# Altium schematic sheet PA0960_IR_Dot_Projector_IR_Flood_LED.SchDoc(PA0960_IR_Dot_Projector_IR_Flood_LED)
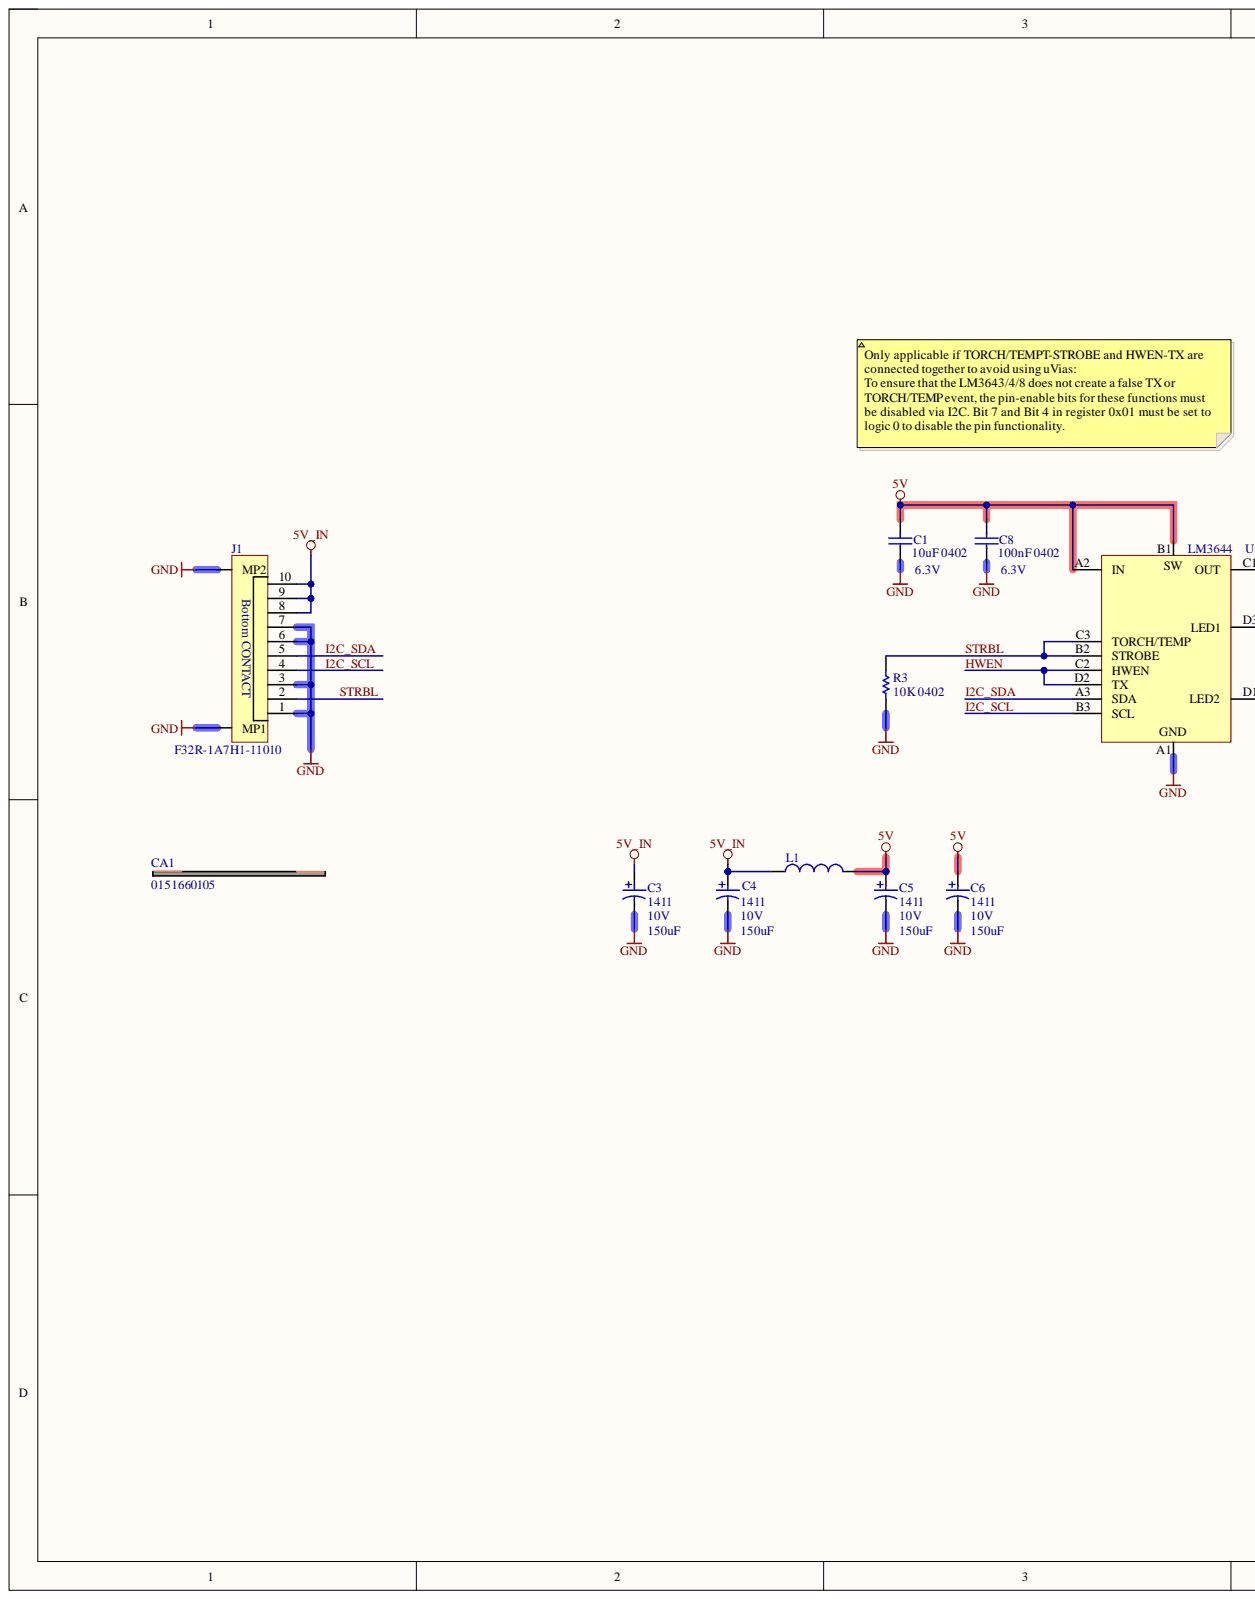
[diagram p0: PA0960_IR_Dot_Projector_IR_Flood_LED.SchDoc, left]
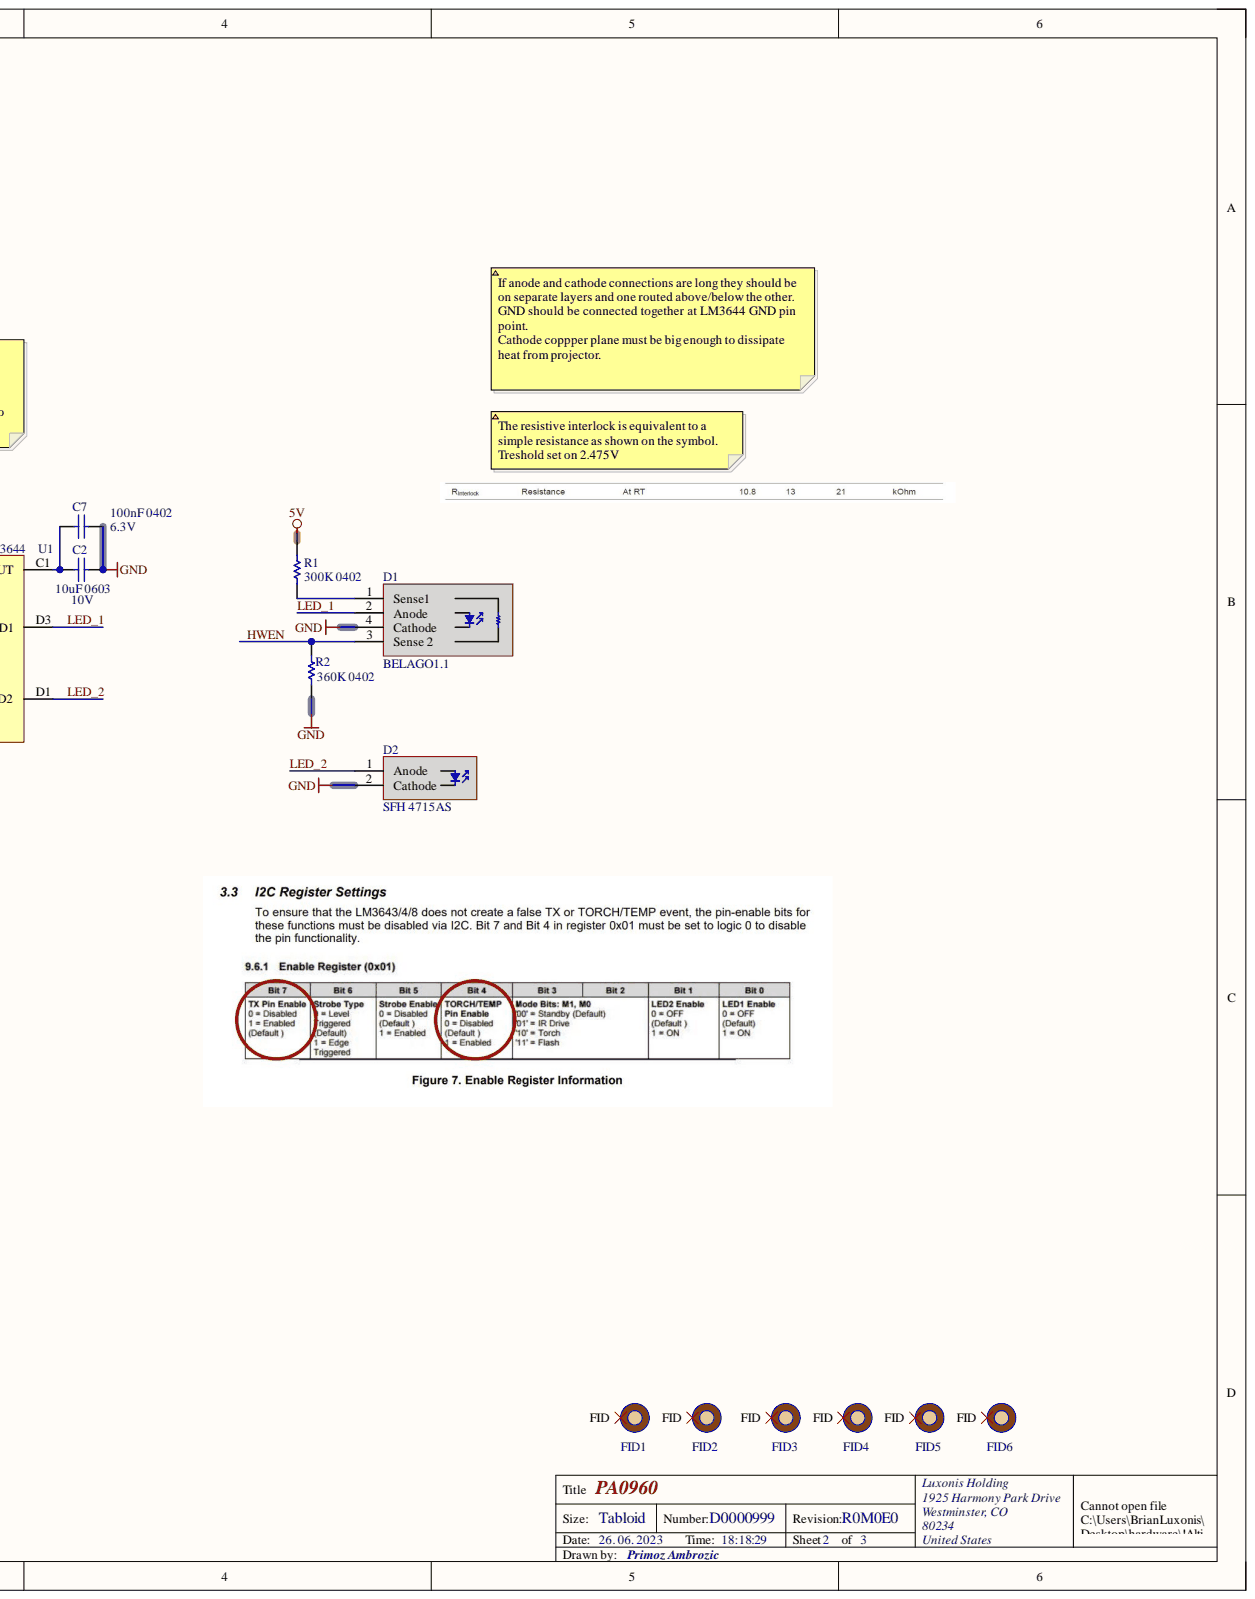
[diagram p1: PA0960_IR_Dot_Projector_IR_Flood_LED.SchDoc, right]

## Parts
C1  10uF 0402  Capacitance: 10uF  Voltage Rating: 6.3V  [CAPC0402(1005)70_N]
C2  10uF 0603  Capacitance: 10uF  Case Code (Imperial): 0603  Voltage Rating: 10V  [CAPC0603(1608)100_N]
C3  Capacitance: 150uF  Rated DC Voltage (URdc): 10V  Size Code: 1411  [RESTL1210(3225)_L]  (hidden comment: 150uF 1206 10V tantal)
C4  Capacitance: 150uF  Rated DC Voltage (URdc): 10V  Size Code: 1411  [RESTL1210(3225)_L]  (hidden comment: 150uF 1206 10V tantal)
C5  Capacitance: 150uF  Rated DC Voltage (URdc): 10V  Size Code: 1411  [RESTL1210(3225)_L]  (hidden comment: 150uF 1206 10V tantal)
C6  Capacitance: 150uF  Rated DC Voltage (URdc): 10V  Size Code: 1411  [RESTL1210(3225)_L]  (hidden comment: 150uF 1206 10V tantal)
C7  100nF 0402  Voltage Rating: 6.3V  [CAPC0402(1005)70_N]
C8  100nF 0402  Case Code (Imperial): 0402  Voltage Rating: 6.3V  [CAPC0402(1005)70_N]
CA1  0151660105
D1  BELAGO1.1  [Belago1.1]
D2  SFH 4715AS  [SFH 4715AS]
FID1  FIDUCIAL_ROUND_1MM  [FIDUCIAL_ROUND_1MM]  (value not drawn)  (no BOM)
FID2  FIDUCIAL_ROUND_1MM  [FIDUCIAL_ROUND_1MM]  (value not drawn)  (no BOM)
FID3  FIDUCIAL_ROUND_1MM  [FIDUCIAL_ROUND_1MM]  (value not drawn)  (no BOM)
FID4  FIDUCIAL_ROUND_1MM  [FIDUCIAL_ROUND_1MM]  (value not drawn)  (no BOM)
FID5  FIDUCIAL_ROUND_1MM  [FIDUCIAL_ROUND_1MM]  (value not drawn)  (no BOM)
FID6  FIDUCIAL_ROUND_1MM  [FIDUCIAL_ROUND_1MM]  (value not drawn)  (no BOM)
J1  F32R-1A7H1-11010  [F32R-1A7H1-11010]
L1  742792011  [SMD-0805]  (value not drawn)
R1  300K 0402  [RESC0402(1005)_L]
R2  360K 0402  [RESC0402(1005)_N]
R3  10K 0402  Case Code (Imperial): 0402  [RESC0402(1005)_L]
U1  LM3644  [LM3644YFFR]

## Nets
5V: C1.2 C5.1 C6.1 C8.2 L1.2 R1.2 U1.A2(IN) U1.B1(SW)
5V_IN: C3.1 C4.1 J1.8 J1.9 J1.10 L1.1
GND: C1.1 C2.2 C3.2 C4.2 C5.2 C6.2 C7.1 C8.1 D1.4(Cathode) D2.2 J1.1 J1.3 J1.6 J1.7 J1.MP1 J1.MP2 R2.1 R3.1 U1.A1
HWEN: D1.3(Sense_2) R2.2 U1.C2(HWEN) U1.D2(TX)
I2C_SCL: J1.4 U1.B3(SCL)
I2C_SDA: J1.5 U1.A3(SDA)
LED_1: D1.2(Anode) U1.D3(LED1)
LED_2: D2.1 U1.D1(LED2)
STRBL: J1.2 R3.2 U1.B2(STROBE) U1.C3(TORCH/TEMP)
NetC2_1: C2.1 C7.2 U1.C1(OUT)
NetD1_1: D1.1(Sense1) R1.1

Not connected: FID1 FID; FID2 FID; FID3 FID; FID4 FID; FID5 FID; FID6 FID

## Library data of the parts
C1 C7 C8 = CMP-004-000009-1: CAP CER 10UF 6.3V X5R 0402 20%; Manufacturer 1: Murata; Manufacturer Part Number 1: GRM155R60J106ME15D; Case Code (Metric): 1005; Case/Package: 0402; Component Kind: Standard; ComponentLink1Description: https://www.murata.com/~/media/webrenewal/support/library/catalog/products/capacitor/mlcc/c02e.ashx?la=en-us&src-supplier=Digi-Key; ComponentLink1URL: https://www.murata.com/~/media/webrenewal/support/library/catalog/products/capacitor/mlcc/c02e.ashx?la=en-us&src-supplier=Digi-Key; Design Item ID: GRM155R60J106ME15D; Dielectric: X5R; Length: 0.039inch; Max Operating Temperature: 85°C; Min Operating Temperature: -55°C; Mount: Surface Mount; Packaging: Tape and Reel; Pricing 1: 1=0.23000, 10=0.15700, 100=0.07950, 500=0.05442, 1000=0.04814, 2500=0.04605, 5000=0.04186 (USD); RoHS: Yes; Stock 1: 1839627; Thickness: 0.026inch; Tolerance: 20%; Voltage Rating (DC): 6.3V; Width: 0.02inch; Library Name: Luxonis LLC; Source: LuxonisMaster.SchLib
  C1: Case Code (Imperial): 0402
  C7: Capacitance: 10uF; Case Code (Imperial): 0402
  C8: Capacitance: 10uF
C2 = CMP-004-000018-1: CAP CER 10UF 10V X5R 0603 20%; Manufacturer 1: Murata; Manufacturer Part Number 1: GRM188R61A106ME69D; Material: Ceramic; Case Code (Metric): 1608; Case/Package: 0603; Component Kind: Standard; ComponentLink1Description: http://www.mouser.com/ds/2/281/c02e-2905.pdf?src-supplier=Mouser; ComponentLink1URL: http://www.mouser.com/ds/2/281/c02e-2905.pdf?src-supplier=Mouser; ComponentLink2Description: http://components.arrow.com/part/dataview/0323_06119472?src-supplier=Arrow+Europe; ComponentLink2URL: http://components.arrow.com/part/dataview/0323_06119472?src-supplier=Arrow+Europe; ComponentLink3Description: http://www.farnell.com/datasheets/2048014.pdf?src-supplier=Farnell; ComponentLink3URL: http://www.farnell.com/datasheets/2048014.pdf?src-supplier=Farnell; ComponentLink4Description: http://www.farnell.com/datasheets/1872210.pdf?src-supplier=Farnell; ComponentLink4URL: http://www.farnell.com/datasheets/1872210.pdf?src-supplier=Farnell; ComponentLink5Description: http://search.murata.co.jp/Ceramy/image/img/A01X/G101/ENG/GRM188R61A106ME69-01.pdf?src-supplier=Digi-Key; ComponentLink5URL: http://search.murata.co.jp/Ceramy/image/img/A01X/G101/ENG/GRM188R61A106ME69-01.pdf?src-supplier=Digi-Key; ComponentLink6Description: http://www.farnell.com/datasheets/1872210.pdf?src-supplier=Newark; ComponentLink6URL: http://www.farnell.com/datasheets/1872210.pdf?src-supplier=Newark; ComponentLink7Description: http://www.farnell.com/datasheets/2048014.pdf?src-supplier=Element14; ComponentLink7URL: http://www.farnell.com/datasheets/2048014.pdf?src-supplier=Element14; ComponentLink8Description: http://www.farnell.com/datasheets/2048014.pdf?src-supplier=Newark; ComponentLink8URL: http://www.farnell.com/datasheets/2048014.pdf?src-supplier=Newark; Depth: 0.8mm; Design Item ID: GRM188R61A106ME69D; Dielectric: X5R; Height: 0.8mm; Lead Free: Lead Free; Length: 1.6mm; Max Operating Temperature: 85°C; Min Operating Temperature: -55°C; Mount: Surface Mount; Package Quantity: 4000; Packaging: Tape and Reel; Pricing 1: 1=0.21000, 10=0.14100, 100=0.07130, 500=0.04876, 1000=0.04313 (USD); REACH SVHC: Unknown; RoHS: Yes; Stock 1: 2873098; Termination: SMD/SMT; Thickness: 0.035inch; Tolerance: 20%; Voltage Rating (DC): 10V; Weight: 0.000222oz; Width: 0.8mm; Library Name: Luxonis LLC; Source: LuxonisMaster.SchLib
C3-C6 = CMP-050-000000-1: Tantalum Capacitor, Polarized, Tantalum (dry/solid), 10V, 20% +Tol, 20% -Tol, 150uF, Surface Mount, 1210; Manufacturer 1: Kyocera AVX; Manufacturer Part Number 1: TLJB157M010R0500; ESR (Equivalent Series Resistance): 500mR; Leakage Current: 15uA; Ripple Current: 371mA; Capacitor Type: Tantalum; Category: Tantalum Capacitors; Component Kind: Standard; Component Type: Standard; ComponentLink1Description: https://datasheet.ciiva.com:443/pdfs/VipMasterIC/IC/AVXC/AVXC-S-A0008508048/AVXC-S-A0008508048-1.pdf?src-supplier=IHS+Markit; ComponentLink1URL: https://datasheet.ciiva.com:443/pdfs/VipMasterIC/IC/AVXC/AVXC-S-A0008508048/AVXC-S-A0008508048-1.pdf?src-supplier=IHS+Markit; ComponentLink2Description: https://datasheet.ciiva.com/264/tlj-264786.pdf?src-supplier=Digi-Key; ComponentLink2URL: https://datasheet.ciiva.com/264/tlj-264786.pdf?src-supplier=Digi-Key; ComponentLink3Description: https://datasheet.ciiva.com/264/tlj-264787.pdf?src-supplier=Digi-Key; ComponentLink3URL: https://datasheet.ciiva.com/264/tlj-264787.pdf?src-supplier=Digi-Key; ComponentLink4Description: https://datasheet.ciiva.com/264/tlj-264788.pdf?src-supplier=Digi-Key; ComponentLink4URL: https://datasheet.ciiva.com/264/tlj-264788.pdf?src-supplier=Digi-Key; ComponentLink5Description: https://datasheet.ciiva.com/46385/1910903-46385237.pdf?src-supplier=Element14; ComponentLink5URL: https://datasheet.ciiva.com/46385/1910903-46385237.pdf?src-supplier=Element14; ComponentLink6Description: https://datasheet.ciiva.com/28515/tlj-28515528.pdf?src-supplier=Element14; ComponentLink6URL: https://datasheet.ciiva.com/28515/tlj-28515528.pdf?src-supplier=Element14; ComponentLink7Description: https://datasheet.ciiva.com/7773/1533257-7773833.pdf?src-supplier=Element14; ComponentLink7URL: https://datasheet.ciiva.com/7773/1533257-7773833.pdf?src-supplier=Element14; ComponentLink8Description: https://datasheet.ciiva.com/14593/70001364-14593673.pdf?src-supplier=Allied+Electronics; ComponentLink8URL: https://datasheet.ciiva.com/14593/70001364-14593673.pdf?src-supplier=Allied+Electronics; ComponentLink9Description: https://datasheet.ciiva.com/14606/70001364-14606409.pdf?src-supplier=Allied+Electronics; ComponentLink9URL: https://datasheet.ciiva.com/14606/70001364-14606409.pdf?src-supplier=Allied+Electronics; ComponentLink10Description: https://datasheet.ciiva.com/7773/1533257-7773834.pdf?src-supplier=Element14; ComponentLink10URL: https://datasheet.ciiva.com/7773/1533257-7773834.pdf?src-supplier=Element14; ComponentLink11Description: https://datasheet.ciiva.com/11229/tlj-11229389.pdf?src-supplier=Avnet; ComponentLink11URL: https://datasheet.ciiva.com/11229/tlj-11229389.pdf?src-supplier=Avnet; ComponentLink12Description: https://datasheet.ciiva.com/17339/tlj-17339422.pdf?src-supplier=Avnet+Europe; ComponentLink12URL: https://datasheet.ciiva.com/17339/tlj-17339422.pdf?src-supplier=Avnet+Europe; Design Item ID: TLJB157M010R0500; Dielectric Material: Tantalum; Height: 1.9mm; JESD-609 Code: e3; Length: 3.5mm; Max Operating Temperature: 125°C; Min Operating Temperature: -55°C; Mount: Surface Mount; Negative Tolerance: 20%; Number of Pins: 2; Package Shape: Rectangular; Package Style: SMTMeter; Packaging: Tape and Reel; Pin Count: 2; Polarity: Polarized; Positive Tolerance: 20%; Pricing 1: 1=0,74000, 10=0,54700, 50=0,43800, 100=0,37540, 500=0,29716, 1000=0,25024 (USD); REACH SVHC: Yes; RoHS: Yes; RoHS Compliant: Yes; Source: DM9095_R3M2E4.SCHLIB; Stock 1: 10000; Terminal Finish: Nickel; Termination: J BEND; Width: 2.8mm; Library Name: Luxonis LLC
CA1 = CMP-00008-1: CABLE FFC 10POS 0.50MM 6"; Manufacturer 1: Molex; Cable Pitch: 0.5mm; Number of Conductors: 10; Pitch: 0.02inch; Wire/Cable Type: Flat Flex; Component Kind: Standard; Design Item ID: 0151660105; Length: 6inch; Manufacturer Part Number 1: 0151660105; Number of Pins: 10; Radiation Hardening: No; RoHS: Yes; Source: Components - 20.09.22 - 15.53.SchLib; Library Name: Luxonis LLC
D1 = CMP-004-00032-1: Dot-Pattern Infrared Illuminator for 3D Stereoscopic  Imaging AQAA-20; Manufacturer 1: AMS; Manufacturer Part Number 1: Belago1.1 AQAA-20; Component Kind: Standard; Design Item ID: BELAGO1.1; Source: DM9098.SchLib; Library Name: Luxonis LLC
D2 = CMP-004-00033-1: OSLON Black Series (850 nm) - 80°; Manufacturer 1: Osram; Ordering code: Q65111A6857; Component Kind: Standard; Design Item ID: SFH 4715AS; Manufacturer Part Number 1: SFH 4715AS; Source: DM9098.SchLib; Library Name: Luxonis LLC
FID1-FID6 = CMP-011-000000-1: Fiducial with various available footprints; Design Item ID: FIDUCIAL; Library Name: Luxonis LLC; Source: LuxonisMaster.SchLib
J1 = CMP-002-00101-1: FPC/FFC Connector Socket 10 Position 0.5mm Bottom Contact Solder Right Angle Surface Mount T/R; Manufacturer 1: Amphenol ICC / FCI; Category: FFC / FPC; Component Kind: Standard; ComponentLink1Description: https://cdn.amphenol-icc.com/media/wysiwyg/files/drawing/f32r-f32j.pdf; ComponentLink1URL: https://cdn.amphenol-icc.com/media/wysiwyg/files/drawing/f32r-f32j.pdf; Design Item ID: F32R-1A7H1-11010; Manufacturer Part Number 1: F32R-1A7H1-11010; Pricing 1: 1=0,53000, 10=0,44300, 50=0,44300, 100=0,38000, 500=0,33100, 1000=0,27300, 2500=0,24800, 5000=0,24000, 10000=0,23700 (USD); RoHS: No; Source: DD2090_4CAM_FFC_R3M1E2.SCHLIB; Stock 1: 35427; Library Name: Luxonis LLC
L1 = CMP-006-00026-1: Ferrite Chip, 1 Function(s), 3A, 2 Pin(s); Manufacturer 1: Wurth electronic; DC Resistance-Max: 25mR; Case Code: 0805; Component Kind: Standard; Current Rating: 3A; Manufacturer Part Number 1: 742792011; Max Operating Temperature: 125°C; Min Operating Temperature: -55°C; RoHS Compliant: Yes; Supplier 1: Digi-Key; Supplier Part Number 1: 732-4635-2-ND; Library Name: Luxonis LLC
R1 R3 = CMP-115-000004-1: RES SMD 10K OHM 1% 0402 1/16W Chip Resistor, 0402 [1005 Metric], 10 kohm, RC Series, 50 V, Thick Film, 62.5 mW; Manufacturer 1: Yageo; Manufacturer Part Number 1: RC0402FR-1310KL; Power Rating: 62.5mW; Resistance: 10kR; Temperature Coefficient: 100ppm/°C; Voltage Rating: 50V; Case Code (Metric): 1005; Case/Package: 0402; Component Kind: Standard; ComponentLink1Description: https://datasheet.ciiva.com/16940/pyu-rc0402-51-rohs-l-6-16940293.pdf?src-supplier=Avnet+Europe; ComponentLink1URL: https://datasheet.ciiva.com/16940/pyu-rc0402-51-rohs-l-6-16940293.pdf?src-supplier=Avnet+Europe; ComponentLink2Description: https://datasheet.ciiva.com/28386/pyu-rc0402-51-rohs-l-6-28386855.pdf?src-supplier=Avnet+Europe; ComponentLink2URL: https://datasheet.ciiva.com/28386/pyu-rc0402-51-rohs-l-6-28386855.pdf?src-supplier=Avnet+Europe; ComponentLink3Description: https://datasheet.ciiva.com/16740/pyu-rc0402-51-rohs-l-6-r-16740602.pdf?src-supplier=Future+Electronics; ComponentLink3URL: https://datasheet.ciiva.com/16740/pyu-rc0402-51-rohs-l-6-r-16740602.pdf?src-supplier=Future+Electronics; ComponentLink4Description: https://datasheet.ciiva.com/8891/pyu-rc0402-51-rohs-l-6-8891339.pdf?src-supplier=Avnet; ComponentLink4URL: https://datasheet.ciiva.com/8891/pyu-rc0402-51-rohs-l-6-8891339.pdf?src-supplier=Avnet; ComponentLink5Description: https://datasheet.ciiva.com/5557/pyu-rc0402-51-rohs-l-6-r-5557997.pdf?src-supplier=Mouser; ComponentLink5URL: https://datasheet.ciiva.com/5557/pyu-rc0402-51-rohs-l-6-r-5557997.pdf?src-supplier=Mouser; ComponentLink6Description: https://datasheet.ciiva.com/7983/1018594-7983533.pdf?src-supplier=Element14; ComponentLink6URL: https://datasheet.ciiva.com/7983/1018594-7983533.pdf?src-supplier=Element14; Composition: Thick Film; Contact Plating: Tin,Matte,Lead; Depth: 0.5mm; Design Item ID: RC0402FR-1310KL; Lead Free: Lead Free; Length: 1mm; Max Operating Temperature: 155°C; Military Standard: Not; Min Operating Temperature: -55°C; Number of Pins: 2; Number of Terminations: 2; Packaging: Tape and Reel; Pricing 1: 1=0.10000, 25=0.01200, 100=0.00400, 250=0.00400, 500=0.00300, 1000=0.00300 (USD); Radiation Hardening: No; REACH SVHC: No SVHC; RoHS: Yes; Source: BW2098POE_1.SCHLIB; Stock 1: 62239; Termination: SMD/SMT; Tolerance: 1%; Voltage Rating (DC): 50V; Working Voltage: 50V; Library Name: Luxonis LLC
  R1: Case Code (Imperial): 0402
R2 = CMP-013-000051-2: Fixed Resistor, Metal Glaze/thick Film, 0.0625W, 360000ohm, 50V, 1% +/-Tol, 100ppm/Cel, Surface Mount, 0402; Manufacturer 1: Yageo; Manufacturer Part Number 1: RC0402FR-07360KL; Rated Power Dissipation (P): 62.5mW; Resistance: 360kR; Category: Chip SMD Resistors; Component Kind: Standard; Design Item ID: RC0402FR-07360KL; Max Operating Temperature: 155°C; Min Operating Temperature: -55°C; Number of Pins: 2; RoHS Compliant: Yes; Tolerance: 1%; Library Name: Luxonis LLC
U1 = CMP-006-000006-1: LED Driver, 2-Segment, PBGA12; Manufacturer 1: Texas Instruments; Data Input Mode: SERIAL; Interface IC Type: LED DISPLAY DRIVER; Max Supply Voltage: 5.5V; Min Supply Voltage: 2.5V; Multiplexed Display Capability: No; Number of Functions: 1; Number of Segments: 2; Package Body Material: Plastic; Supply Voltage-Nom: 3.6V; Category: LED Drivers; Component Kind: Standard; ComponentLink1Description: https://www.ti.com:443/lit/ds/symlink/lm3644.pdf?src-supplier=IHS+Markit; ComponentLink1URL: https://www.ti.com:443/lit/ds/symlink/lm3644.pdf?src-supplier=IHS+Markit; ComponentLink2Description: https://datasheet.ciiva.com/48475/lm3644-48475318.pdf?src-supplier=Avnet; ComponentLink2URL: https://datasheet.ciiva.com/48475/lm3644-48475318.pdf?src-supplier=Avnet; Design Item ID: LM3644; Height - Seated (Max): 0.625mm; JESD-609 Code: e1; Length: 1.66mm; Manufacturer Part Number 1: LM3644YFFR; Max Operating Temperature: 85°C; Min Operating Temperature: -40°C; Moisture Sensitivity Level: 1; Number of Pins: 12; Package Code: VFBGA; Package Shape: Rectangular; Package Style: GRID ARRAY, VERY THIN PROFILE, FINE PITCHMeter; Peak Reflow Temperature (Cel): 260°C; Pricing 1: 1=0,64580, 10=0,63600, 25=0,62590, 100=0,61590, 250=0,60610, 500=0,59600, 1000=0,58600, 3000=0,35000, 30000=0,34520, 300000=0,31610, 1500000=0,29870, 3000000=0,29850 (USD); REACH SVHC: Yes; RoHS: Yes; RoHS Compliant: Yes; Source: DM9098.SchLib; Stock 1: 2033; Surface Mount: Yes; Temperature Grade: INDUSTRIAL; Terminal Finish: Copper; Terminal Form: Ball; Terminal Pitch: 0.4mm; Terminal Position: BOTTOM; Width: 1.28mm; Library Name: Luxonis LLC
